# Altium schematic sheet Sheet1.SchDoc(Sheet1)
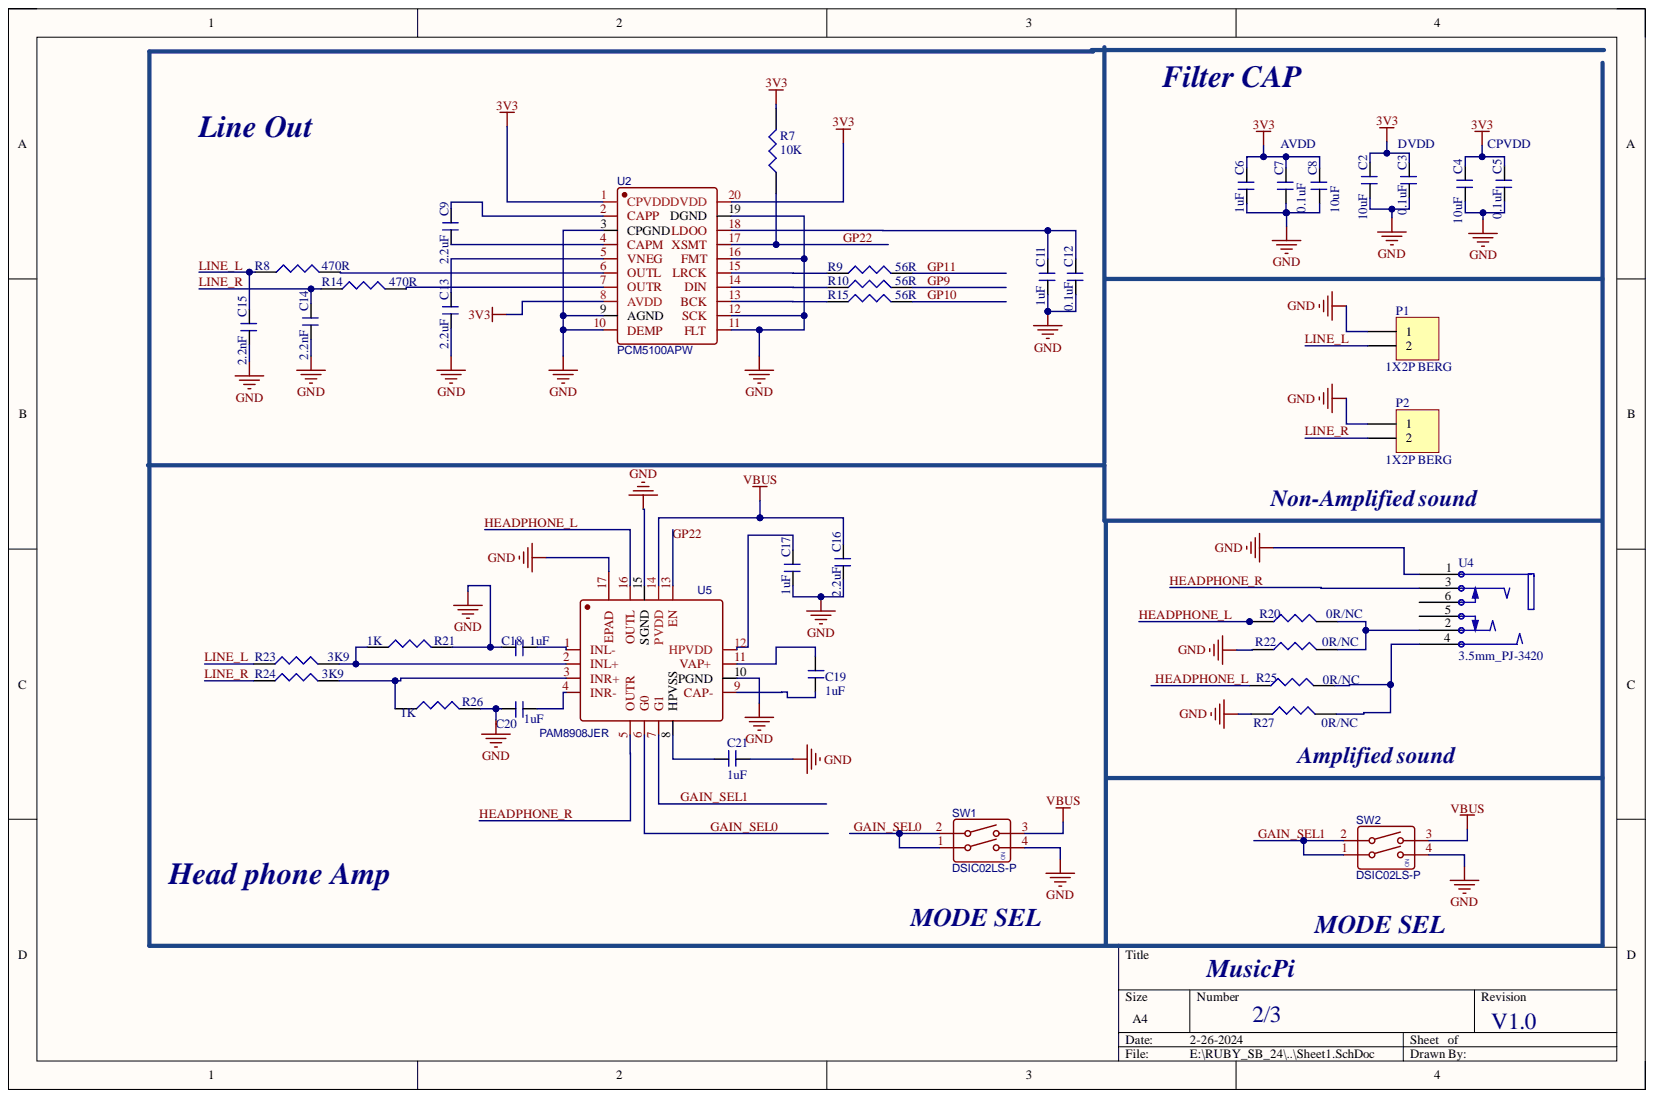
[diagram p0: Sheet1.SchDoc]

## Parts
C2  10uF  [C_0603]
C3  0.1uF  [C_0603]
C4  10uF  [C_0603]
C5  0.1uF  [C_0603]
C6  1uF  [C_0603]
C7  0.1uF  [C_0603]
C8  10uF  [C_0603]
C9  2.2uF  [C_0603]
C11  1uF  [C_0603]
C12  0.1uF  [C_0603]
C13  2.2uF  [C_0603]
C14  2.2nF  [C_0603]
C15  2.2nF  [C_0603]
C16  2.2uF  [C_0603]
C17  1uF  [C_0603]
C18  1uF  [C_0603]
C19  1uF  [C_0603]
C20  1uF  [C_0603]
C21  1uF  [C_0603]
P1  1X2P BERG  [2P_2.54MM]
P2  1X2P BERG  [2P_2.54MM]
R7  10K  [R_0603]
R8  470R  [R_0603]
R9  56R  [R_0603]
R10  56R  [R_0603]
R14  470R  [R_0603]
R15  56R  [R_0603]
R20  0R/NC  [R_0603]
R21  1K  [R_0603]
R22  0R/NC  [R_0603]
R23  3K9  [R_0603]
R24  3K9  [R_0603]
R25  0R/NC  [R_0603]
R26  1K  [R_0603]
R27  0R/NC  [R_0603]
SW1  DSIC02LS-P  [SW_ SMD-2P]
SW2  DSIC02LS-P  [SW_ SMD-2P]
U2  PCM5100APW  [TSSOP 20P]
U4  3.5mm_PJ-3420  [3.5mm_PJ-3420]
U5  PAM8908JER  [VQFN-16-EP(3x3)]

## Nets
3V3: C2.1 C3.1 C4.1 C5.1 C6.1 C7.1 C8.1 R7.2 U2.1(CPVDD) U2.8(AVDD) U2.20(DVDD)
GND: C2.2 C3.2 C4.2 C5.2 C6.2 C7.2 C8.2 C11.2 C12.2 C13.2 C14.2 C15.2 C16.2 C17.2 C18.1 C20.1 C21.1 P1.1 P2.1 R21.2 R22.2 R26.2 R27.2 SW1.4 SW2.4 U2.3(CPGND) U2.9(AGND) U2.10(DEMP) U2.11(FLT) U2.12(SCK) U2.16(FMT) U2.19(DGND) U4.1 U5.10(PGND) U5.15(SGND) U5.17(EPAD)
VBUS: C16.1 SW1.3 SW2.3 U5.14(PVDD)
GAIN_SEL0: SW1.1 SW1.2 U5.6(G0)
GAIN_SEL1: SW2.1 SW2.2 U5.7(G1)
HEADPHONE_L: R20.2 R25.2 U5.16(OUTL)
HEADPHONE_R: U4.3 U5.5(OUTR)
I2S_BCK: R15.2
I2S_DATA: R10.2
I2S_LRCK: R9.2
LINE_L: C15.1 P1.2 R8.1 R23.1
LINE_R: C14.1 P2.2 R14.1 R24.1
MUTE: R7.1 U2.17(XSMT) U5.13(EN)
NetC9_1: C9.1 U2.2(CAPP)
NetC9_2: C9.2 U2.4(CAPM)
NetC11_1: C11.1 C12.1 U2.18(LDOO)
NetC13_1: C13.1 U2.5(VNEG)
NetC17_1: C17.1 U5.12(HPVDD)
NetC18_2: C18.2 U5.1(INL-)
NetC19_1: C19.1 U5.9(CAP-)
NetC19_2: C19.2 U5.11(VAP+)
NetC20_2: C20.2 U5.4(INR-)
NetC21_2: C21.2 U5.8(HPVSS)
NetR8_2: R8.2 U2.6(OUTL)
NetR9_1: R9.1 U2.15(LRCK)
NetR10_1: R10.1 U2.14(DIN)
NetR14_2: R14.2 U2.7(OUTR)
NetR15_1: R15.1 U2.13(BCK)
NetR20_1: R20.1 R22.1 U4.2
NetR21_1: R21.1 R23.2 U5.2(INL+)
NetR24_2: R24.2 R26.1 U5.3(INR+)
NetR25_1: R25.1 R27.1 U4.4

Not connected: U4 5 6

## Library data of the parts
C2-C9 C11-C21 = CAP_NONPOLAR: Component Kind: Standard; Library Name: dxp_pasl_lib.IntLib
P1 P2 = Header 2H: Header, 2-Pin, Right Angle; Component Kind: Standard; LatestRevisionDate: 17-Jul-2002; LatestRevisionNote: Re-released for DXP Platform.; Library Name: Miscellaneous Connectors.IntLib; Signal Integrity: Connector; Publisher: Altium Limited
R7-R10 R14 R15 R20-R27 = RES: Component Kind: Standard; Library Name: dxp_pasl_lib.IntLib
SW1 SW2 = NDI-02H-V: BOM_Manufacturer: 圜达; Contributor: LCSC; BOM_JLCPCB Part Class: Extended Part; BOM_Manufacturer Part: NDI-02H-V; BOM_Supplier: LCSC; BOM_Supplier Part: C224999; Component Kind: Standard; spicePre: S; spiceSymbolName: NDI-02H-V
U2 = PCM5100APW: BOM_Manufacturer: TI(德州仪器); Contributor: LCSC; BOM_Manufacturer Part: PCM5100APW; BOM_Supplier: LCSC; BOM_Supplier Part: C544856; Component Kind: Standard; spicePre: U; spiceSymbolName: PCM5100APW; Library Name: MIX.SchLib
U4 = Headphone Holder SMD: Component Kind: Standard; Library Name: dxp_pasl_lib.IntLib
U5 = PAM8908JER: BOM_Manufacturer: DIODES(美台); Contributor: LCSC; BOM_JLCPCB Part Class: Extended Part; BOM_Manufacturer Part: PAM8908JER; BOM_Supplier: LCSC; BOM_Supplier Part: C33233; Component Kind: Standard; spicePre: U; spiceSymbolName: PAM8908JER; Library Name: MIX.SchLib
